# Altium schematic sheet MOSFET.SchDoc(MOSFET)
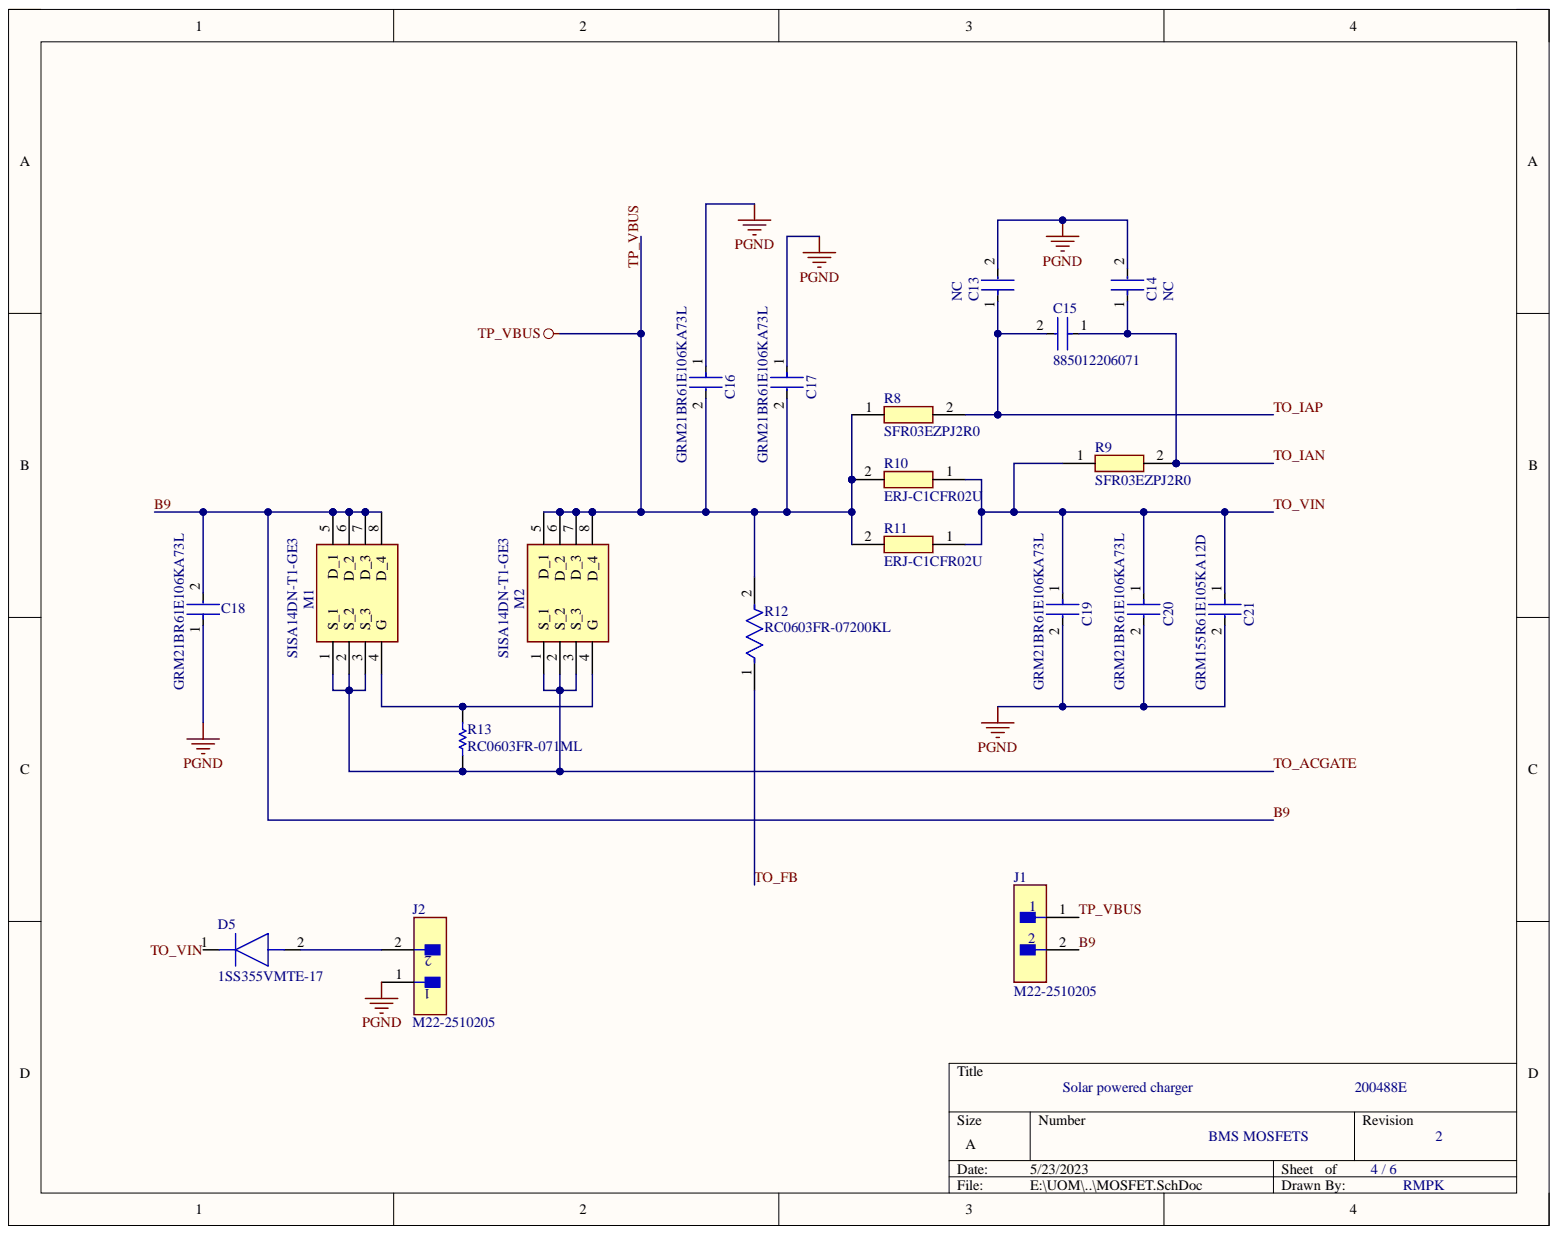
[diagram p0: MOSFET.SchDoc]

## Parts
C13  NC  [FP-885012206071-MFG]
C14  NC  [FP-885012206071-MFG]
C15  885012206071  [FP-885012206071-MFG]
C16  GRM21BR61E106KA73L  [FP-GRM21B-0_15-IPC_C]
C17  GRM21BR61E106KA73L  [FP-GRM21B-0_15-IPC_C]
C18  GRM21BR61E106KA73L  [FP-GRM21B-0_15-IPC_C]
C19  GRM21BR61E106KA73L  [FP-GRM21B-0_15-IPC_C]
C20  GRM21BR61E106KA73L  [FP-GRM21B-0_15-IPC_C]
C21  GRM155R61E105KA12D  [FP-GRM155-0_05-IPC_B]
D5  1SS355VMTE-17  [UDZVTE1722B]
J1  M22-2510205  [FP-M22-2510205-MFG]
J2  M22-2510205  [FP-M22-2510205-MFG]
M1  SISA14DN-T1-GE3  [SQ7414AENT1GE3]
M2  SISA14DN-T1-GE3  [SQ7414AENT1GE3]
R8  SFR03EZPJ2R0  [RESC1608X55N]
R9  SFR03EZPJ2R0  [RESC1608X55N]
R10  ERJ-C1CFR02U  [ERJC1]
R11  ERJ-C1CFR02U  [ERJC1]
R12  RC0603FR-07200KL  [FP-RC0603-0_55-IPC_B]
R13  RC0603FR-071ML  [RESC1608X55X25LL10T15]

## Nets
PGND: C13.2 C14.2 C16.1 C17.1 C18.1 C19.2 C20.2 C21.2 J2.1
TO_ACGATE: M1.1(S_1) M1.2(S_2) M1.3(S_3) M2.1(S_1) M2.2(S_2) M2.3(S_3) R13.1
TO_ADP: C18.2 M1.5(D_1) M1.6(D_2) M1.7(D_3) M1.8(D_4)
TO_FB: R12.1
TO_IAN: C14.1 C15.1 R9.2
TO_IAP: C13.1 C15.2 R8.2
TO_VIN: C19.1 C20.1 C21.1 D5.1 R9.1 R10.1 R11.1
TP_VBUS: C16.2 C17.2 J1.1 M2.5(D_1) M2.6(D_2) M2.7(D_3) M2.8(D_4) R8.1 R10.2 R11.2 R12.2
TP_VBUSC: J1.2
NetD5_2: D5.2 J2.2
NetM1_4: M1.4(G) M2.4(G) R13.2

## Library data of the parts
C13-C15 = CMP-207839-000033-1: CAP CER 0.1UF 25V X7R 0603; Capacitance: 100nF; Tolerance: 10%; Case Code (Imperial): 0603; Case Code (Metric): 1608; Case/Package: 0603; Component Kind: Standard; Dielectric: X7R; Length: 0.063inch; Library Name: Altium Content Vault; Max Operating Temperature: 125°C; Min Operating Temperature: -55°C; Mount: Surface Mount; Packaging: Cut Tape; RoHS Compliant: Yes; Series: WCAP-CSGP; Thickness: 0.037inch; Voltage Rating: 25V; Voltage Rating (DC): 25V; Width: 0.031inch
C16-C20 = CMP-2007-03541-2: Chip Multilayer Ceramic Capacitors for General Purpose, 0805, 10uF, X5R, 15%, 10%, 25V; Capacitance: 10uF; Case Code (Imperial): 0805; Case Code (Metric): 2012; Case/Package: 0805; Component Kind: Standard; Depth: 1.25mm; Dielectric: X5R; Height: 1.25mm; Lead Free: Lead Free; Length: 2mm; Library Name: Altium Content Vault; Material: Ceramic; Max Operating Temperature: 85°C; Min Operating Temperature: -55°C; Mount: Surface Mount; Package Quantity: 1; Packaging: Tape and Reel; Radiation Hardening: No; Termination: SMD/SMT; Thickness: 0.053inch; Tolerance: 10%; Voltage: 25V; Voltage Rating: 25V; Voltage Rating (DC): 25V; Width: 0.049inch
C21 = CMP-2008-04581-2: Chip Multilayer Ceramic Capacitors for General Purpose, 0402, 1.0uF, X5R, 15%, 10%, 25V; Capacitance: 1uF; Case Code (Imperial): 0402; Case Code (Metric): 1005; Case/Package: 0402; Component Kind: Standard; Depth: 0.5mm; Dielectric: X5R; Height: 0.5mm; Lead Free: Lead Free; Length: 1mm; Library Name: Altium Content Vault; Material: Ceramic; Max Operating Temperature: 85°C; Min Operating Temperature: -55°C; Mount: Surface Mount; Package Quantity: 10000; Packaging: Tape and Reel; REACH SVHC: No SVHC; Termination: SMD/SMT; Thickness: 0.022inch; Tolerance: 10%; Voltage: 25V; Voltage Rating: 25V; Voltage Rating (DC): 25V; Weight: 0.000212oz; Width: 0.5mm
D5 = 1SS355VMTE-17: Diode; Manufacturer_Name: ROHM Semiconductor; Component Kind: Standard; Datasheet Link: https://datasheet.datasheetarchive.com/originals/distributors/Datasheets_SAMA/726503b2436a9ce4bc644e4966373f1b.pdf; Height: 0mm; Manufacturer_Part_Number: 1SS355VMTE-17; Mouser Part Number: 755-1SS355VMTE-17; Mouser Price/Stock: https://www.mouser.co.uk/ProductDetail/ROHM-Semiconductor/1SS355VMTE-17?qs=IsRgwgmxh693733hapxfjA%3D%3D
J1 J2 = CMP-15831-000005-1: CONN HEADER VERT 2POS 2MM; Manufacturer: Harwin; Conn Gender: Male; Conn Orientation: Vertical; Current Rating: 2A; Number Of Contacts: 2; Automotive: No; Category: Conn; Component Kind: Standard; ComponentLink2Description: Datasheet; ComponentLink2URL: https://cdn.harwin.com/pdfs/C018XX_M22_Series_Connectors.pdf; Contact Material: Gold,Nickel; Device Class L1: Connectors; Device Class L2: Headers and Wire Housings; Device Class L3: unset; Digikey Description: CONN HEADER VERT 2POS 2MM; Footprint Url: https://cdn.harwin.com/pdfs/M22-251.pdf; Height: 5.45mm; Lead Free: Yes; Library Name: Altium Content Vault; Manufacturer Part Number: M22-2510205; Mouser Description: Headers & Wire Housings 2 PIN SIL VERTICAL GOLD PIN HEADER; Number Of Rows: 1; Octopart: https://octopart.com/m22-2510205-harwin-2538221; Package: 0; Pitch: 2mm; RoHS: TRUE; Temperature: -40°C to +105°C; Temperature Range High: +105°C; Temperature Range Low: -40°C
M1 M2 = SISA14DN-T1-GE3: MOSFET (N-Channel); Manufacturer_Name: Vishay; Arrow Part Number: SISA14DN-T1-GE3; Arrow Price/Stock: https://www.arrow.com/en/products/sisa14dn-t1-ge3/vishay?region=nac; Component Kind: Standard; Datasheet Link: https://www.vishay.com/docs/63252/sisa14dn.pdf; Manufacturer_Part_Number: SISA14DN-T1-GE3; Mouser Part Number: 78-SISA14DN-T1-GE3; Mouser Price/Stock: https://www.mouser.co.uk/ProductDetail/Vishay-Siliconix/SISA14DN-T1-GE3?qs=mtIy1uf5%252BARMEfEzOtCo6A%3D%3D
R8 R9 = SFR03EZPJ2R0: Resistor; Manufacturer_Name: ROHM Semiconductor; Component Kind: Standard; Datasheet Link: https://www.mouser.com/datasheet/2/348/ROHM_S_A0009648217_1-2563033.pdf; Height: 0.55mm; Manufacturer_Part_Number: SFR03EZPJ2R0
R10 R11 = ERJ-C1CFR02U: Resistor; Manufacturer_Name: Panasonic; Component Kind: Standard; Datasheet Link: https://industrial.panasonic.com/cdbs/www-data/pdf/RDP0000/AOA0000C340.pdf; Height: 0.75mm; Manufacturer_Part_Number: ERJ-C1CFR02U; Mouser Part Number: 667-ERJ-C1CFR02U; Mouser Price/Stock: https://www.mouser.co.uk/ProductDetail/Panasonic/ERJ-C1CFR02U?qs=Z3CaLxJiOJL4jODaXLT4ow%3D%3D
R12 = CMP-03412-037786-1: RES SMD 200K OHM 1% 1/10W 0603; Max Power Dissipation: 100mW; Resistance: 200kR; Temperature Coefficient: 100ppm/°C; Voltage Rating: 50V; Case Code (Imperial): 0603; Case Code (Metric): 1608; Case/Package: 0603; Component Kind: Standard; Construction: Rectangular; Depth: 0.8mm; Features: Moisture Resistant; Height: 0.022inch; Lead Free: Lead Free; Length: 1.6mm; Library Name: Altium Content Vault; Max Operating Temperature: 155°C; Military Standard: Not; Min Operating Temperature: -55°C; Number of Pins: 2; Number of Terminations: 2; Package Quantity: 1; Packaging: Tape and Reel; Power Rating: 100mW; REACH SVHC: No SVHC; Resistance Tolerance: 1%; Resistor Type: Thick Film; RoHS Compliant: Yes; Series: RC; Size Code: 0603; Termination: SMD/SMT; Tolerance: 1%; Voltage Rating (DC): 50V; Width: 0.031inch
R13 = CMP-1659-00056-1: Chip Resistor, 1 MOhm, +/- 1%, 100 mW, -55 to 155 degC, 0603 (1608 Metric), RoHS, Tape and Reel; Capacitance: 1 mF; Case\Package: Radial; Tolerance: 20%; Voltage Rating: 25 V; Component Kind: Standard; ComponentLink1Description: Manufacturer URL; ComponentLink1URL: http://www.yageo.com/; ComponentLink2Description: Datasheet; ComponentLink2URL: http://www.yageo.com/documents/recent/PYu-RC_Group_51_RoHS_L_04.pdf; DatasheetVersion: Rev. 4, 02/2016; Diameter: 10 mm; Height: 20 mm; Lead Pitch: 5 mm; Length: 20 mm; Library Name: Altium Content Vault; Max Operating Temperature: 85 degC; Min Operating Temperature: -40 degC; Mount: Through Hole; Package Quantity: 200; PackageDescription: 2-Pin Surface Mount Device, Body 1.6 x 0.8 mm; PackageReference: 0603; Packaging: Bulk; Radiation Hardening: No; REACH SVHC: No SVHC; RoHSCompliant: true; Voltage Rating (DC): 25 V; Width: 0 inch
